# Altium schematic sheet WS2812B.SchDoc(WS2812B)
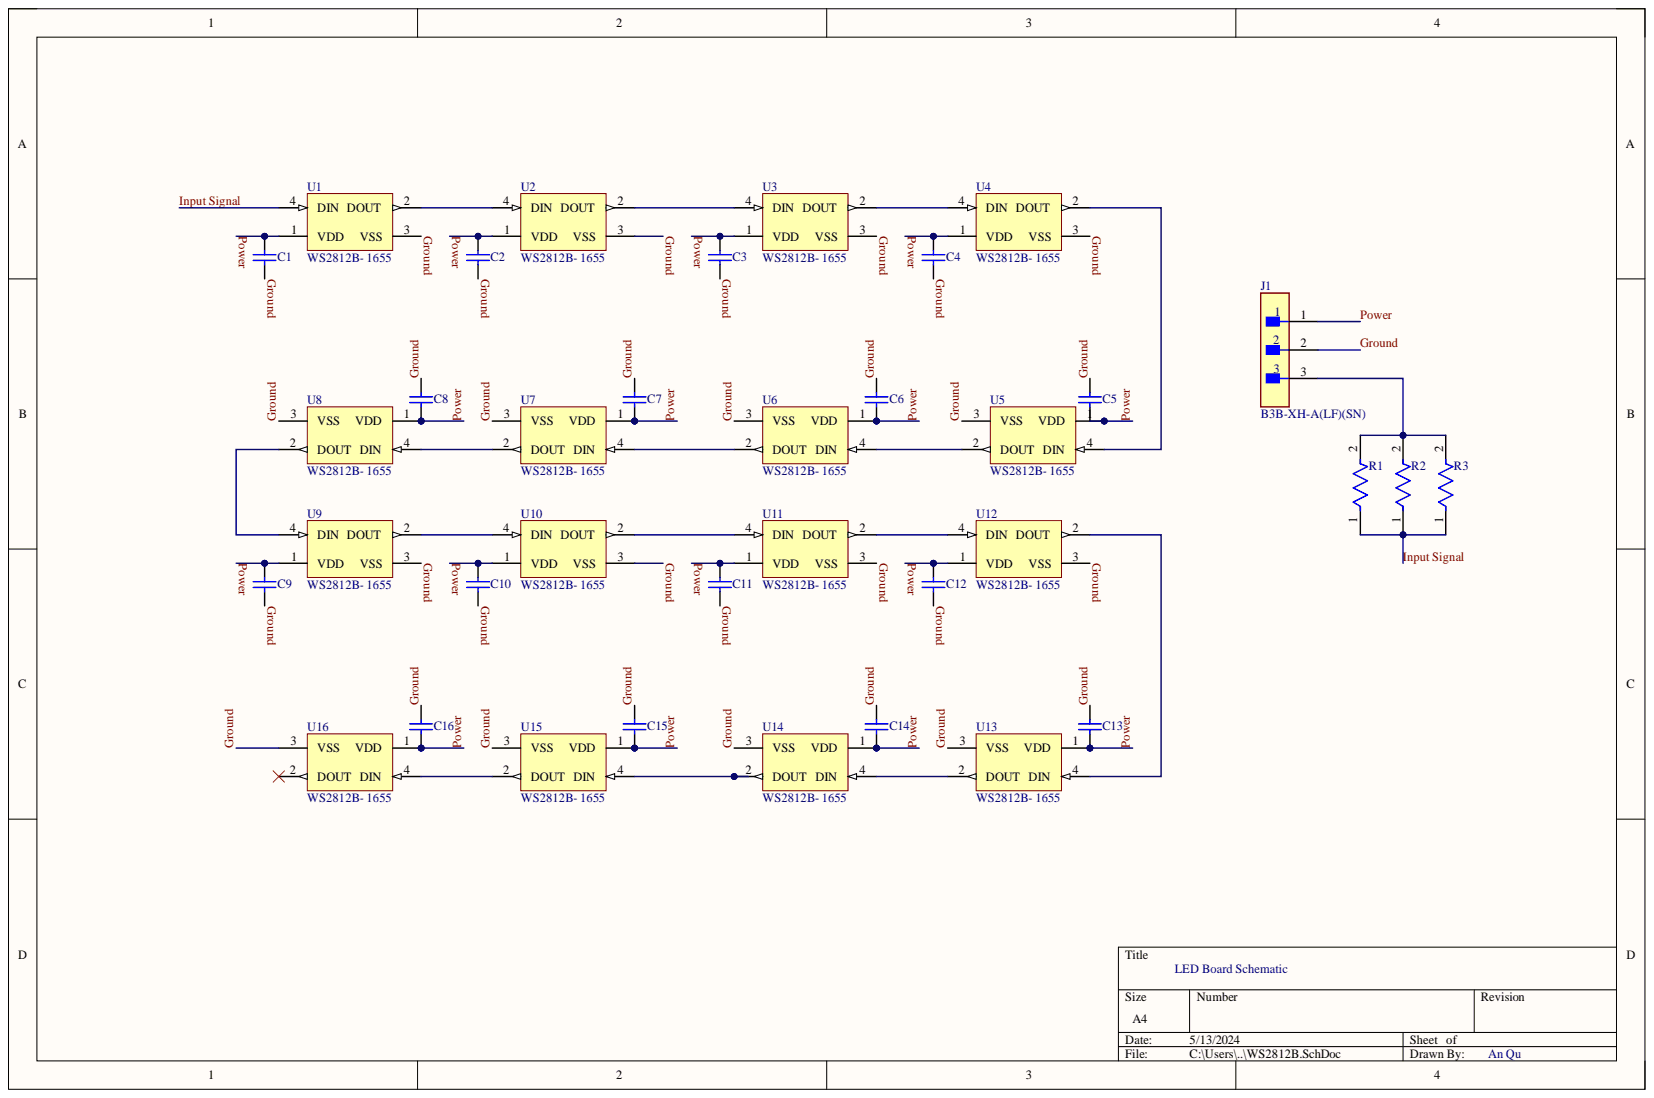
[diagram p0: WS2812B.SchDoc]

## Parts
C1  K104K15X7RF5TL2  [VISH-K104K15X7RF5TL2]  (value not drawn)
C2  K104K15X7RF5TL2  [VISH-K104K15X7RF5TL2]  (value not drawn)
C3  K104K15X7RF5TL2  [VISH-K104K15X7RF5TL2]  (value not drawn)
C4  K104K15X7RF5TL2  [VISH-K104K15X7RF5TL2]  (value not drawn)
C5  K104K15X7RF5TL2  [VISH-K104K15X7RF5TL2]  (value not drawn)
C6  K104K15X7RF5TL2  [VISH-K104K15X7RF5TL2]  (value not drawn)
C7  K104K15X7RF5TL2  [VISH-K104K15X7RF5TL2]  (value not drawn)
C8  K104K15X7RF5TL2  [VISH-K104K15X7RF5TL2]  (value not drawn)
C9  K104K15X7RF5TL2  [VISH-K104K15X7RF5TL2]  (value not drawn)
C10  K104K15X7RF5TL2  [VISH-K104K15X7RF5TL2]  (value not drawn)
C11  K104K15X7RF5TL2  [VISH-K104K15X7RF5TL2]  (value not drawn)
C12  K104K15X7RF5TL2  [VISH-K104K15X7RF5TL2]  (value not drawn)
C13  K104K15X7RF5TL2  [VISH-K104K15X7RF5TL2]  (value not drawn)
C14  K104K15X7RF5TL2  [VISH-K104K15X7RF5TL2]  (value not drawn)
C15  K104K15X7RF5TL2  [VISH-K104K15X7RF5TL2]  (value not drawn)
C16  K104K15X7RF5TL2  [VISH-K104K15X7RF5TL2]  (value not drawn)
J1  B3B-XH-A(LF)(SN)  [FP-B3B-XH-A_LF_SN-MFG]
R1  MRS25000C1000FRP00  [FP-MRS25-MFG]  (value not drawn)
R2  MRS25000C1000FRP00  [FP-MRS25-MFG]  (value not drawn)
R3  MRS25000C1000FRP00  [FP-MRS25-MFG]  (value not drawn)
U1  WS2812B- 1655  [ADA-RGB-1655_V]
U2  WS2812B- 1655  [ADA-RGB-1655_V]
U3  WS2812B- 1655  [ADA-RGB-1655_V]
U4  WS2812B- 1655  [ADA-RGB-1655_V]
U5  WS2812B- 1655  [ADA-RGB-1655_V]
U6  WS2812B- 1655  [ADA-RGB-1655_V]
U7  WS2812B- 1655  [ADA-RGB-1655_V]
U8  WS2812B- 1655  [ADA-RGB-1655_V]
U9  WS2812B- 1655  [ADA-RGB-1655_V]
U10  WS2812B- 1655  [ADA-RGB-1655_V]
U11  WS2812B- 1655  [ADA-RGB-1655_V]
U12  WS2812B- 1655  [ADA-RGB-1655_V]
U13  WS2812B- 1655  [ADA-RGB-1655_V]
U14  WS2812B- 1655  [ADA-RGB-1655_V]
U15  WS2812B- 1655  [ADA-RGB-1655_V]
U16  WS2812B- 1655  [ADA-RGB-1655_V]

## Nets
Ground: C1.2 C2.2 C3.2 C4.2 C5.1 C6.1 C7.1 C8.1 C9.2 C10.2 C11.2 C12.2 C13.1 C14.1 C15.1 C16.1 J1.2 U1.3(VSS) U2.3(VSS) U3.3(VSS) U4.3(VSS) U5.3(VSS) U6.3(VSS) U7.3(VSS) U8.3(VSS) U9.3(VSS) U10.3(VSS) U11.3(VSS) U12.3(VSS) U13.3(VSS) U14.3(VSS) U15.3(VSS) U16.3(VSS)
Input Signal: R1.1 R2.1 R3.1 U1.4(DIN)
Power: C1.1 C2.1 C3.1 C4.1 C5.2 C6.2 C7.2 C8.2 C9.1 C10.1 C11.1 C12.1 C13.2 C14.2 C15.2 C16.2 J1.1 U1.1(VDD) U2.1(VDD) U3.1(VDD) U4.1(VDD) U5.1(VDD) U6.1(VDD) U7.1(VDD) U8.1(VDD) U9.1(VDD) U10.1(VDD) U11.1(VDD) U12.1(VDD) U13.1(VDD) U14.1(VDD) U15.1(VDD) U16.1(VDD)
NetJ1_3: J1.3 R1.2 R2.2 R3.2
NetU1_2: U1.2(DOUT) U2.4(DIN)
NetU2_2: U2.2(DOUT) U3.4(DIN)
NetU3_2: U3.2(DOUT) U4.4(DIN)
NetU4_2: U4.2(DOUT) U5.4(DIN)
NetU5_2: U5.2(DOUT) U6.4(DIN)
NetU6_2: U6.2(DOUT) U7.4(DIN)
NetU7_2: U7.2(DOUT) U8.4(DIN)
NetU8_2: U8.2(DOUT) U9.4(DIN)
NetU9_2: U9.2(DOUT) U10.4(DIN)
NetU10_2: U10.2(DOUT) U11.4(DIN)
NetU11_2: U11.2(DOUT) U12.4(DIN)
NetU12_2: U12.2(DOUT) U13.4(DIN)
NetU13_2: U13.2(DOUT) U14.4(DIN)
NetU14_2: U14.2(DOUT) U15.4(DIN)
NetU15_2: U15.2(DOUT) U16.4(DIN)

Not connected: U16 2(DOUT)

## Library data of the parts
C1-C16 = CMP-2000-06508-1: Ceramic Capacitor, 100 nF, +/- 10%, 50 V, -55 to 125 degC, 2-Pin THD, RoHS, Tape and Reel; Manufacturer: Vishay; Capacitance: 0.1µF; Catalog Drawings: Mono-KapSide2-15_5.58Mono-KapSide1_15; Online Catalog: Mono-Kap™Series; Other Names: BC1084CT; Temperature Coefficient: X7R; Voltage - Rated: 50V; Applications: GeneralPurpose; Category: Capacitors; Component Kind: Standard; Datasheet URL: http://www.vishay.com/docs/45171/kseries.pdf; Datasheet Version: 08/2013; Family: CeramicCapacitors; Features: -; Height - Seated (Max): 0.220"(5.58mm); Lead Spacing: 0.098"(2.50mm); Lead Style: Straight; Library Name: Altium Content Vault; Manufacturer URL: http://www.vishay.com/; Mounting Technology: ThroughHole; Mounting Type: ThroughHole; Operating Temperature: -55°C~125°C; Package / Case: Radial; Package Description: 2-Pin Through Hole Device, Body 4 x 2.6 mm; Package Reference: K104K15X7RF5TL2; Packaging: CutTape(CT); Ratings: -; Series: Mono-Kap™K; Size / Dimension: 0.157"Lx0.098"W(4.00mmx2.50mm); Standard Package: 1; Thickness (Max): -; Tolerance: ±10%
J1 = CMP-17439-000014-3: CONN HEADER VERT 3POS 2.5MM; Contact Resistance: 20mR; Current Rating: 3A; Gender: Male; Insulation Resistance: 1GR; Max Voltage Rating (AC): 250V; Number of Contacts: 3; Wire/Cable Gauge: 22AWG; Color: Natural; Component Kind: Standard; Connector Type: Header; Contact Finish - Mating: TIN; Contact Material: Brass; Contact Plating: Tin; Contact Shape: Square; Contact Type: Male Pin; Depth: 5.75mm; Fastening Type: Detent; Flammability Rating: UL94 V-0; Housing Color: White; Housing Material: Polyamide; Insulation Color: Natural; Insulation Material: Nylon; Lead Free: Lead Free; Lead Pitch: 2.5mm; Length: 9.9mm; Library Name: Altium Content Vault; Max Current Rating: 3A; Max Operating Temperature: 85°C; Max Voltage Rating (DC): 250V; Min Operating Temperature: -25°C; Mount: Through Hole; Number of Positions: 3; Number of Rows: 1; Orientation: Straight; Packaging: Bulk; Pitch: 2.5mm; REACH SVHC: No SVHC; Series: XH; Termination: Solder; Voltage Rating: 250V; Voltage Rating (AC): 250V
R1-R3 = CMP-00030-42757237-1: MRS25000C1000FRP00 Resistor, 100 Ohm, ± 1%, 600 mW, Axial Leaded, Metal Film (Thin Film), Professional; Lead Diameter: 600µm; Max Power Dissipation: 600mW; Resistance: 100Ω; Temperature Coefficient: 50ppm/°C; Voltage Rating: 350V; Case/Package: Axial; Component Kind: Standard; Composition: Metal Film, Thin Film; Contact Plating: Tin; Diameter: 2.5mm; Lead Free: Lead Free; Length: 6.5mm; Library Name: Altium Content Vault; Max Operating Temperature: 155°C; Military Standard: Not; Min Operating Temperature: -55°C; Mount: Through Hole; NexarPartId: 42757237; Number of Pins: 2; Number of Terminations: 2; Packaging: Tape & Reel (TR); Power Rating: 600mW; Radiation Hardening: No; REACH SVHC: Unknown; RoHS: Compliant; Schedule B: 8533210090, 8533210090; Termination: Axial; Tolerance: 1%; Voltage Rating (DC): 350V; Width: 2.5mm
U1-U16 = CMP-00327-1: WS2812B 5050 RGB LED WITH INTEGRATED DRIVER CHIP PACK OF 10; Case/Package (Imperial): 5050; Forward Current: 60mA; Forward Voltage: 5V; Colour: RGB; Component Kind: Standard; Manufacturer Part Number: WS2812B; Mounting Technology: SMD; Library Name: APAC- Monash Nova Rover
